# Altium schematic sheet bdk2m_comm.SchDoc
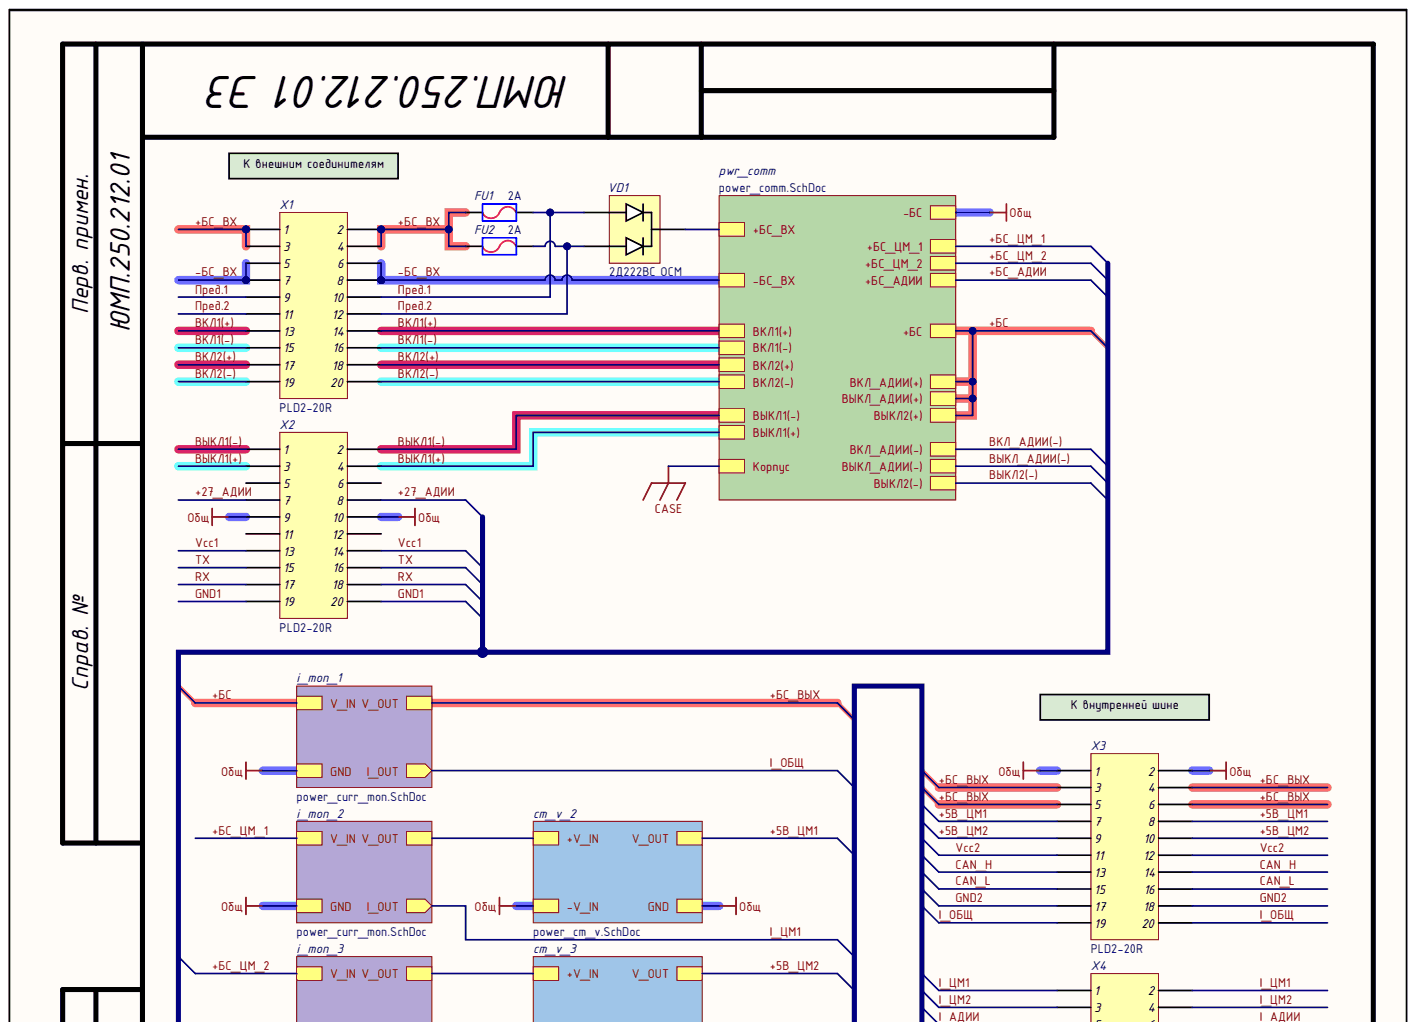
[diagram p0: bdk2m_comm.SchDoc, top]
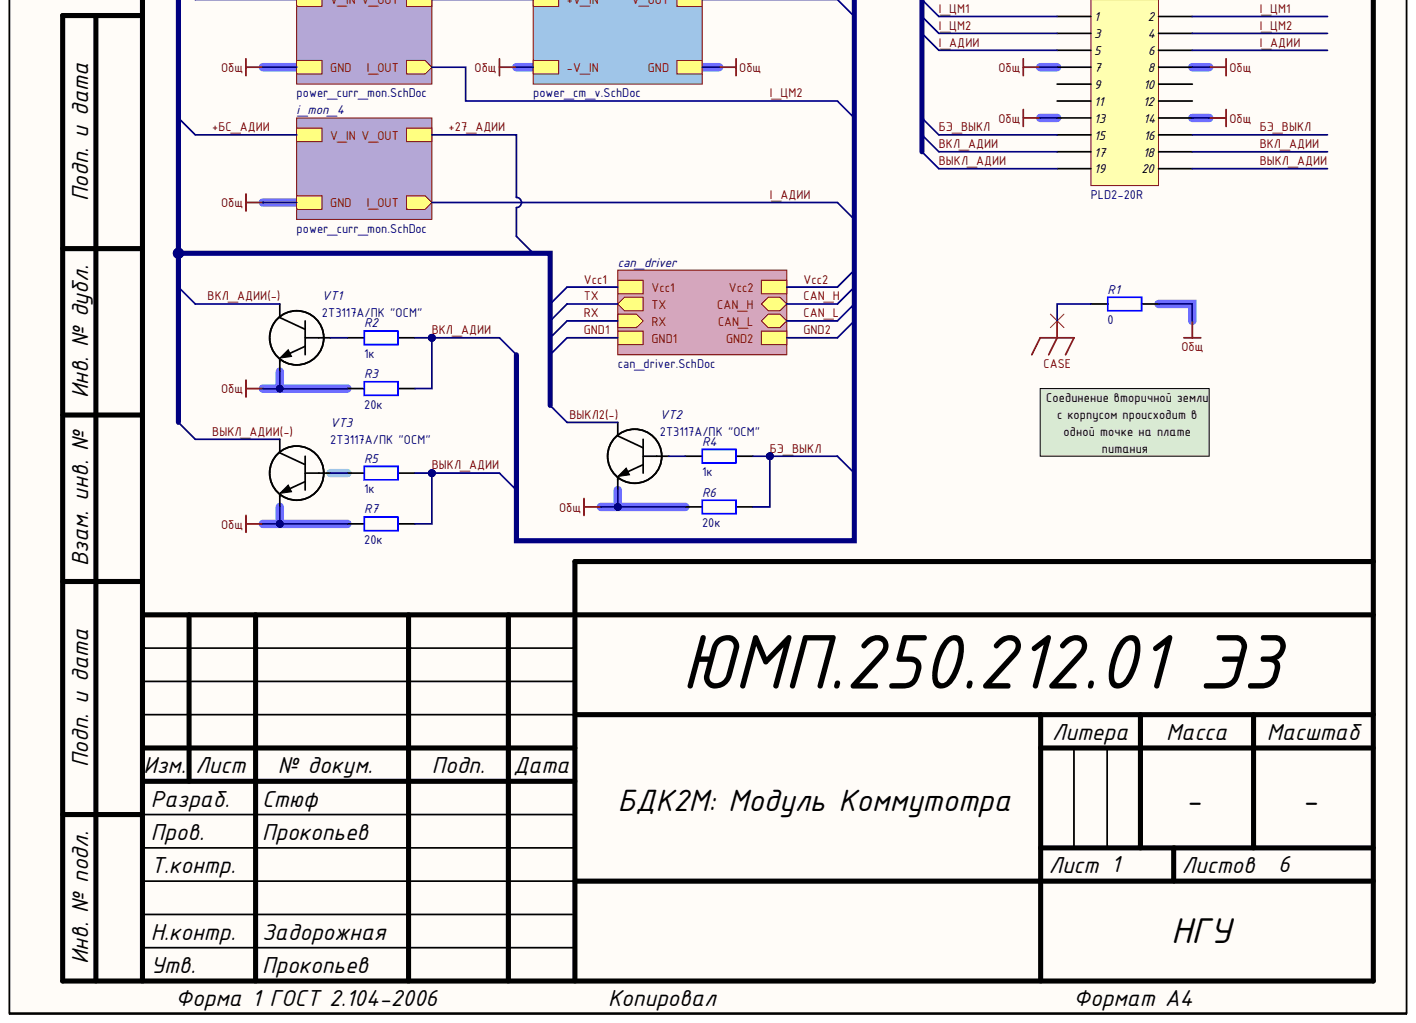
[diagram p1: bdk2m_comm.SchDoc, bottom]

## Parts
FU1  value 2A  [VP1-2]  (hidden comment: VP1-2)
FU2  value 2A  [VP1-2]  (hidden comment: VP1-2)
R1  0  [SMD_0805]
R2  1к  [SMD_0805]
R3  20к  [SMD_0805]
R4  1к  [SMD_0805]
R5  1к  [SMD_0805]
R6  20к  [SMD_0805]
R7  20к  [SMD_0805]
VD1  Наименование: 2Д222ВС ОСМ  [4116.4-3_offcut]  (hidden comment: 2D222VS "OSM")
VT1  2T3117A  [TO-18]  (value not drawn)
VT2  2T3117A  [TO-18]  (value not drawn)
VT3  2T3117A  [TO-18]  (value not drawn)
X1  Header 2X10  [PLD2-20R]  (value not drawn)
X2  Header 2X10  [PLD2-20R]  (value not drawn)
X3  Header 2X10  [PLD2-20R]  (value not drawn)
X4  Header 2X10  [PLD2-20R]  (value not drawn)

## Nets
CASE: R1.1
Общ: R1.2 R3.1 R6.1 R7.1 VT1.1 VT2.1 VT3.1 X2.9 X2.10 X3.1 X3.2 X4.7 X4.8 X4.13 X4.14
+5В_ЦМ1: X3.7 X3.8
+5В_ЦМ2: X3.9 X3.10
+27_АДИИ: X2.7 X2.8
+БС_ВХ: FU1.1 FU2.1 X1.1 X1.2 X1.3 X1.4
+БС_ВЫХ: X3.3 X3.4 X3.5 X3.6
-БС_ВХ: X1.5 X1.6 X1.7 X1.8
CAN_H: X3.13 X3.14
CAN_L: X3.15 X3.16
GND1: X2.19 X2.20
GND2: X3.17 X3.18
I_АДИИ: X4.5 X4.6
I_ОБЩ: X3.19 X3.20
I_ЦМ1: X4.1 X4.2
I_ЦМ2: X4.3 X4.4
RX: X2.17 X2.18
TX: X2.15 X2.16
Vcc1: X2.13 X2.14
Vcc2: X3.11 X3.12
БЭ_ВЫКЛ: R4.2 R6.2 X4.15 X4.16
ВКЛ1(+): X1.13 X1.14
ВКЛ1(-): X1.15 X1.16
ВКЛ2(+): X1.17 X1.18
ВКЛ2(-): X1.19 X1.20
ВКЛ_АДИИ: R2.2 R3.2 X4.17 X4.18
ВКЛ_АДИИ(-): VT1.3
ВЫКЛ1(+): X2.3 X2.4
ВЫКЛ1(-): X2.1 X2.2
ВЫКЛ2(-): VT2.3
ВЫКЛ_АДИИ: R5.2 R7.2 X4.19 X4.20
ВЫКЛ_АДИИ(-): VT3.3
Пред.1: FU1.2 VD1.11 X1.9 X1.10
Пред.2: FU2.2 VD1.17 X1.11 X1.12
NetR2_1: R2.1 VT1.2
NetR4_1: R4.1 VT2.2
NetR5_1: R5.1 VT3.2
NetVD1_8: VD1.8
NetX2_5: X2.5
NetX2_6: X2.6
NetX2_11: X2.11
NetX2_12: X2.12
NetX4_9: X4.9
NetX4_10: X4.10
NetX4_11: X4.11
NetX4_12: X4.12
+V_IN:
+БС:
+БС_АДИИ:
+БС_ЦМ_1:
+БС_ЦМ_2:

## Library data of the parts
FU1 FU2 = VP1-2: Предохранитель плавкий; Наименование: ВП1-2 "ВП"; Component Kind: Standard; ComponentLink1Description: DataSheet; ComponentLink1URL: https://docviewer.yandex.ru/view/20572601/?page=1&*=oYyHA%2BCwzeyRvrmOJV6%2ByaBlbHJ7InVybCI6Imh0dHBzOi8vZWwtcmEucnUvdXBsb2FkL2libG9jay8wMTYvdnN0YXZrYS1wbGF2a2EtdnAxXzItX2VsZWt0cm9uaWthX3JhXy5wZGYiLCJ0aXRsZSI6InZzdGF2a2EtcGxhdmthLXZwMV8yLV9lbGVrdHJvbmlrYV9yYV8ucGRmIiwibm9pZnJhbWUiOnRydWUsInVpZCI6IjIwNTcyNjAxIiwidHMiOjE1OTI0NzI0NTM1MTUsInl1IjoiODg5MDYxMzMxNTQzMzg3MTIxIiwic2VycFBhcmFtcyI6Imxhbmc9cnUmdG09MTU5MjQ3MjQyOCZ0bGQ9cnUmbmFtZT12c3RhdmthLXBsYXZrYS12cDFfMi1fZWxla3Ryb25pa2FfcmFfLnBkZiZ0ZXh0PSVEMCVCMiVEMCVCRjEtMiZ1cmw9aHR0cHMlM0EvL2VsLXJhLnJ1L3VwbG9hZC9pYmxvY2svMDE2L3ZzdGF2a2EtcGxhdmthLXZwMV8yLV9lbGVrdHJvbmlrYV9yYV8ucGRmJmxyPTY1Jm1pbWU9cGRmJmwxMG49cnUmc2lnbj0yZWMwNDRiZDQyMGRlMjRmMjI1MThkNTc4YjZlMTlkYiZrZXlubz0wIn0%3D&lang=ru; Library Name: VP1-2.Schlib; Назначение: Предохранитель плавкий
R1-R7 = Resistor: Назначение: SMD-резистор; Наименование: Резистор; Потребление, Вт: 0,001; Component Kind: Standard; Description: Resistor; Library Name: Passive.IntLib
  R1: Value: 0
  R2 R4 R5: Value: 1к
  R3 R6 R7: Value: 20к
VD1 = 2D222: Сдвоенный диод; Потребление, Вт: 0,004; Component Kind: Standard; ComponentLink1Description: DataSheet; ComponentLink1URL: https://eandc.ru/pdf/diod/2d222.pdf; Назначение: Сдвоенный диод; Library Name: Diod.IntLib
VT1-VT3 = 2T3117A: Кремниевый биполярный  эпитаксиально-планарный  n-p-n транзистор; Назначение: Биполярный транзистор; Наименование: 2Т3117А/ПК "ОСМ"; Потребление, Вт: 0,0; Component Kind: Standard; ComponentLink1Description: DataSheet; ComponentLink1URL: https://www.integral.by/sites/default/files/pdf/2t3117.pdf; Library Name: Transistor.IntLib
X1-X4 = PLD2-20: Header, 12-Pin, Dual row; Назначение: Header, 20-Pin, Dual row; Потребление, Вт: 0,0; Component Kind: Standard; Library Name: Connector.IntLib; Наименование: PLD2-20R
